# Altium schematic sheet Connectors.SchDoc(Connectors)
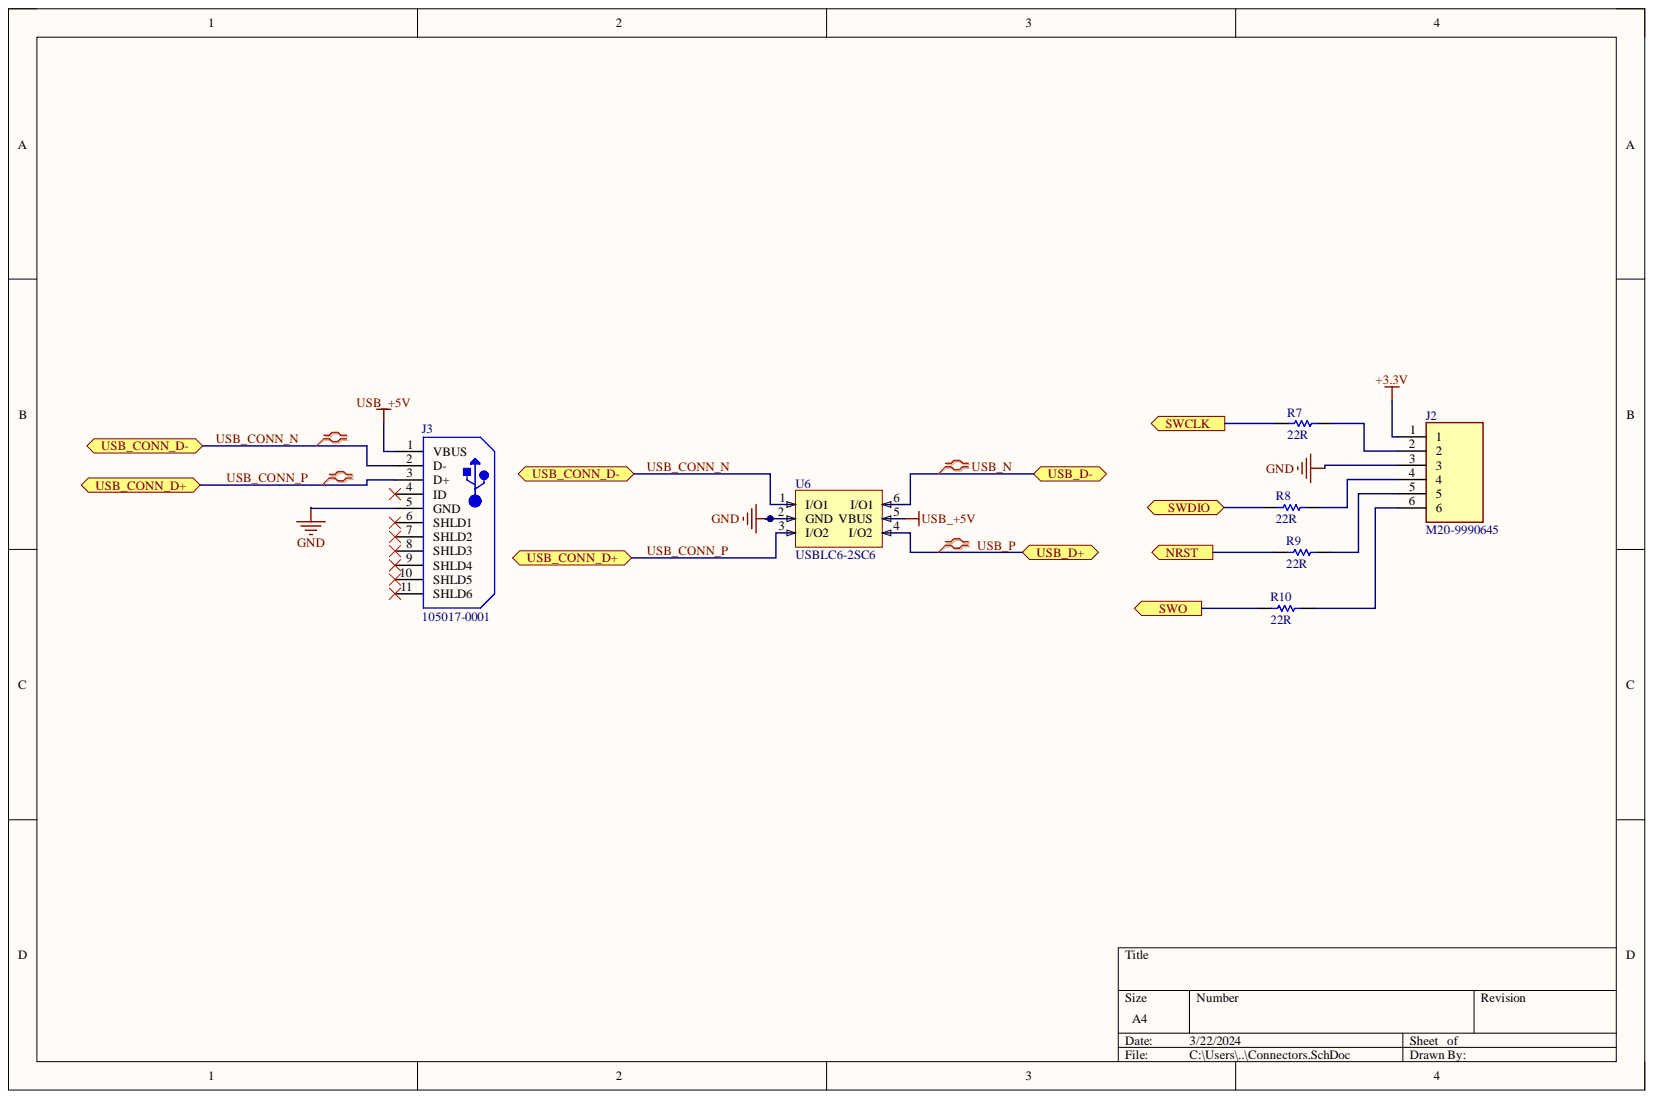
[diagram p0: Connectors.SchDoc]

## Parts
J2  M20-9990645  [M20-9990645-Footprint-1]
J3  105017-0001  [USB-MICRO-B_V]
R7  22R  [RESC3216X60X45ML10T20]
R8  22R  [RESC3216X60X45ML10T20]
R9  22R  [RESC3216X60X45ML10T20]
R10  22R  [RESC3216X60X45ML10T20]
U6  USBLC6-2SC6  [STM-SOT23-6L_V]

## Ports
NRST, SWCLK, SWDIO, SWO, USB_CONN_D+, USB_CONN_D-, USB_D+, USB_D-

## Nets
+3.3V: J2.1
GND: J2.3 J3.5(GND) U6.2(GND)
USB_+5V: J3.1(VBUS) U6.5(VBUS)
NRST: R9.1 ; port NRST
SWCLK: R7.1 ; port SWCLK
SWDIO: R8.1 ; port SWDIO
SWO: R10.1 ; port SWO
USB_CONN_N: J3.2(D-) U6.1(I/O1)
USB_CONN_P: J3.3(D+) U6.3(I/O2)
USB_N: U6.6(I/O1)
USB_P: U6.4(I/O2)
NetJ2_2: J2.2 R7.2
NetJ2_4: J2.4 R8.2
NetJ2_5: J2.5 R9.2
NetJ2_6: J2.6 R10.2

Not connected: J3 4(ID) 6(SHLD1) 7(SHLD2) 8(SHLD3) 9(SHLD4) 10(SHLD5) 11(SHLD6)

## Library data of the parts
J2 = CMP-002-00072-1: Board Connector, 6 Contact(s), 1 Row(s), Male, Straight, 0.1 inch Pitch, Solder Terminal, Locking, Black Insulator; Component Kind: Standard; Connector Type: BOARD CONNECTOR; Max Operating Temperature: 105°C; Min Operating Temperature: -40°C; Mounting Technology: Board; RoHS Compliant: Yes; Library Name: tutorial_PCB
J3 = CMP-2000-05827-1: Micro-USB B Receptacle, Right Angle, Bottom Mount, Surface Mount, with Solder Tabs, -30 to 85 degC, 5-Pin USB, RoHS, Tape and Reel; Manufacturer: Molex; 3D Model: 105017-0001.stp; Catalog Drawings: 1050170001; Circuits: 5; Connector Type: USB-microB; Featured Product: Micro-USBConnectorsUSBConnectors; Mating Products: WM17145-ND-CABLEMICROUSBBTOSTDA1.0MWM17147-ND-CABLEMICROUSBBTOSTDA2.0MWM17146-ND-CABLEMICROUSBBTOSTDA1.5M; Online Catalog: Molex-MicroUSB; Other Names: WM1399DKR; Package Version: Rev. F, 12/2012; PCN Assembly/Origin: ResinSupplier21/Oct/2015; Voltage - Max: 30V; Category: Connectors,Interconnects; Component Kind: Standard; Datasheet URL: http://www.molex.com/elqNow/elqRedir.htm?ref=http://www.molex.com/webdocs/datasheets/pdf/en-us/1050170001_IO_CONNECTORS.pdf; Datasheet Version: 03/2016; Family: USB,DVI,HDMIConnectors; Features: Shielded,SolderRetention; Gender: Receptacle; Ingress Protection: -; Library Name: Altium Content Vault; Manufacturer URL: http://www.molex.com/; Mounting Technology: SurfaceMount; Mounting Type: BoardCutout,SurfaceMount,RightAngle; Number of Contacts: 5; Package Description: 5-Leads USB, Micro B, Body 7.5 x 5 mm, Pitch 0.65 mm; Package Reference: MICRO-B; Package URL: http://www.molex.com/pdm_docs/sd/1050170001_sd.pdf; Packaging: Digi-Reel®; RoHS Information: 1050170001CertofCompliance; Series: -; Specifications: -; Standard Package: 1
R7-R10 = CMP-2003-01966-1: Manufacturer: Vishay Dale; Part Number: CRCW120622R0FKEA; Component Kind: Standard; Library Name: Altium Content Vault
U6 = CMP-00024-00006-1: Trans Voltage Suppressor Diode, 5V V(RWM), Unidirectional, 1 Element, Silicon; Component Kind: Standard; Max Operating Temperature: 125°C; Min Operating Temperature: -40°C; Pins: 6; RoHS Compliant: Yes; Library Name: tutorial_PCB
